# Altium schematic sheet Motor.SchDoc
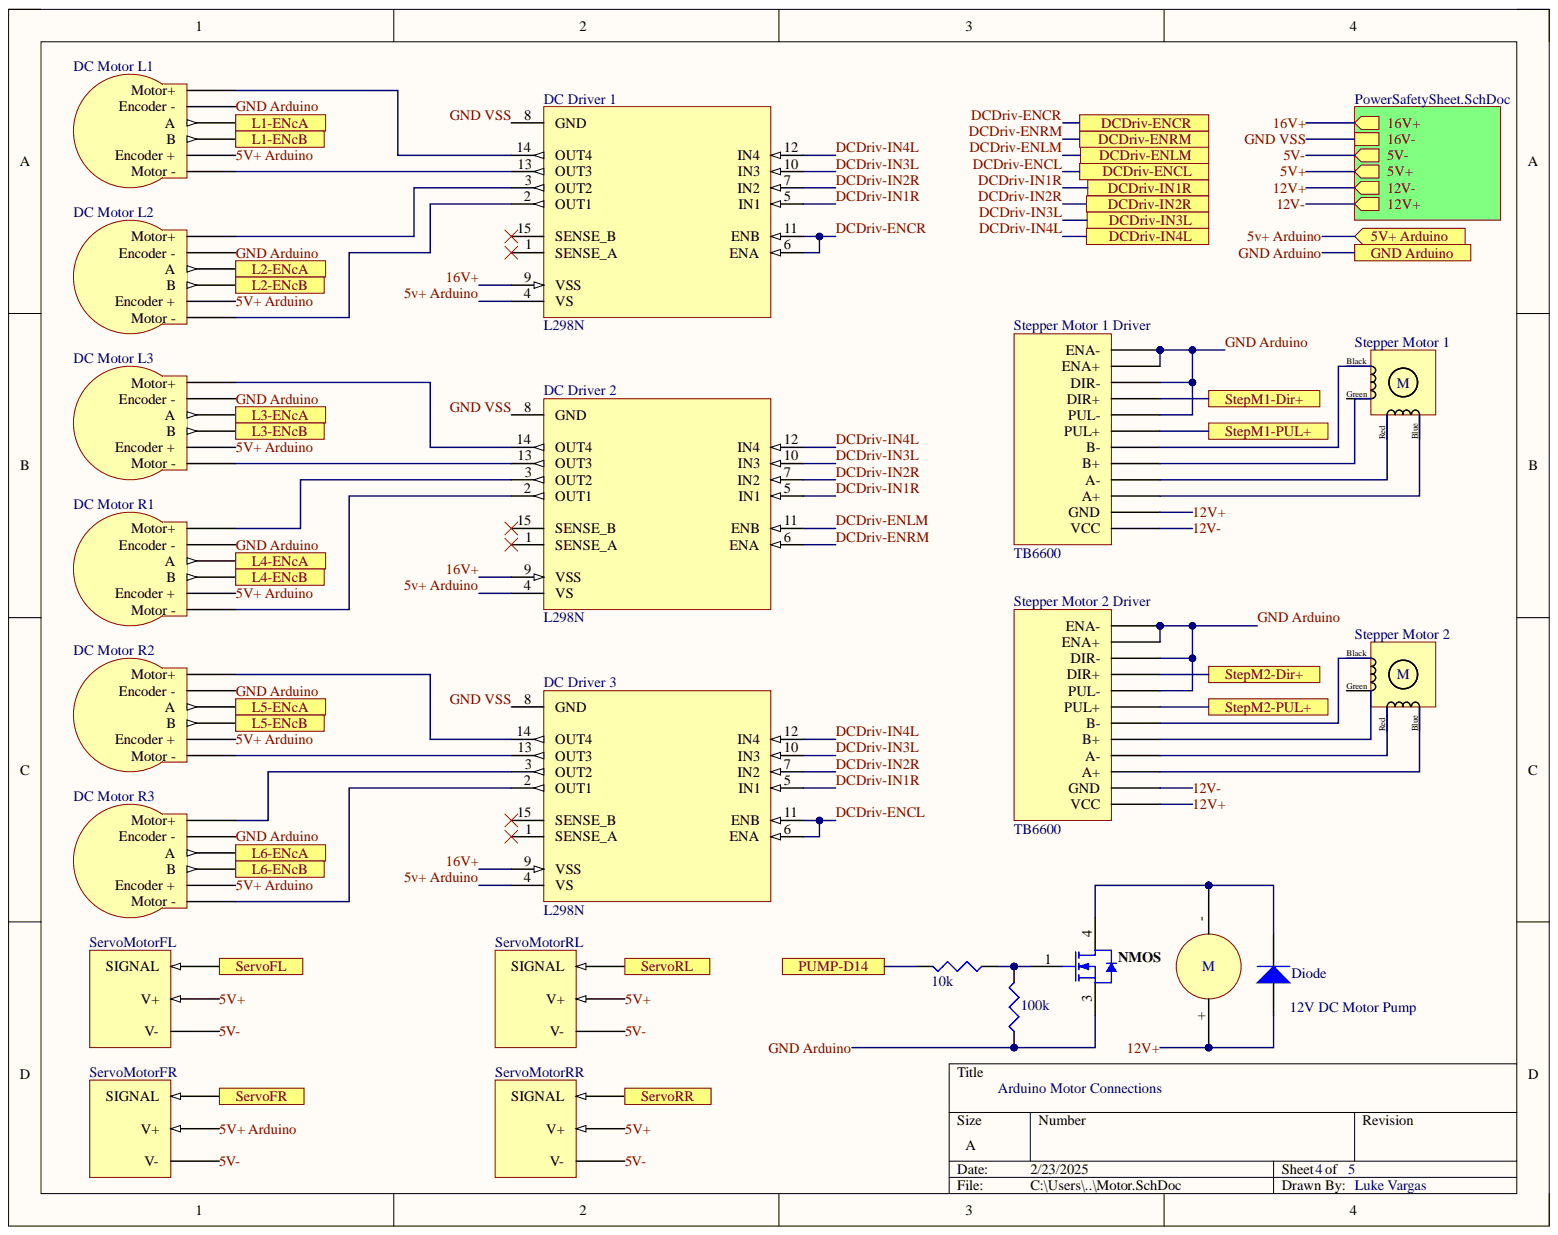
[diagram p0: Motor.SchDoc]

## Parts
10k  value 100k  [RESA60-630X240]  (hidden comment: MFR-25FBF52-10K)
12V_DC_Motor_Pump  12V DC Motor Pump
?  value 10k  [RESA60-630X240]  (hidden comment: MFR-25FBF52-10K)
DC_Driver_1  L298N  [L298N]
DC_Driver_2  L298N  [L298N]
DC_Driver_3  L298N  [L298N]
DC_Motor_L1  MotorWithEncoder  (value not drawn)
DC_Motor_L2  MotorWithEncoder  (value not drawn)
DC_Motor_L3  MotorWithEncoder  (value not drawn)
DC_Motor_R1  MotorWithEncoder  (value not drawn)
DC_Motor_R2  MotorWithEncoder  (value not drawn)
DC_Motor_R3  MotorWithEncoder  (value not drawn)
Diode  1N4007  [FAIR-DO-41-2]  (value not drawn)
NMOS  NTD3055L104G  [ONSC-DPAK-3-369C_V]  (value not drawn)
ServoMotorFL  ServoMotor
ServoMotorFR  ServoMotor
ServoMotorRL  ServoMotor
ServoMotorRR  ServoMotor
Stepper_Motor_1  Stepper Motor 1
Stepper_Motor_1_Driver  TB6600
Stepper_Motor_2  Stepper Motor 2
Stepper_Motor_2_Driver  TB6600

## Ports
5V+ Arduino, DCDriv-ENCL, DCDriv-ENCR, DCDriv-ENLM, DCDriv-ENRM, DCDriv-IN1R, DCDriv-IN2R, DCDriv-IN3L, DCDriv-IN4L, GND Arduino, L1-ENcA, L1-ENcB, L2-ENcA, L2-ENcB, L3-ENcA, L3-ENcB, L4-ENcA, L4-ENcB, L5-ENcA, L5-ENcB, L6-ENcA, L6-ENcB, PUMP-D14, ServoFL, ServoFR, ServoRL, ServoRR, StepM1-Dir+, StepM1-PUL+, StepM2-Dir+, StepM2-PUL+

## Nets
5V+: ServoMotorFL.+ ServoMotorRL.+ ServoMotorRR.+
5v+ Arduino: DC_Driver_1.4(VS) DC_Driver_2.4(VS) DC_Driver_3.4(VS) DC_Motor_L1.Encoder + DC_Motor_L2.Encoder + DC_Motor_L3.Encoder + DC_Motor_R1.Encoder + DC_Motor_R2.Encoder + DC_Motor_R3.Encoder + ServoMotorFR.+ ; port 5V+ Arduino
5V-: ServoMotorFL.- ServoMotorFR.- ServoMotorRL.- ServoMotorRR.-
12V+: 12V_DC_Motor_Pump.+ Diode.2 Stepper_Motor_1_Driver.GND Stepper_Motor_2_Driver.VCC
12V-: Stepper_Motor_1_Driver.VCC Stepper_Motor_2_Driver.GND
16V+: DC_Driver_1.9(VSS) DC_Driver_2.9(VSS) DC_Driver_3.9(VSS)
DCDriv-ENCL: DC_Driver_3.6(ENA) DC_Driver_3.11(ENB) ; port DCDriv-ENCL
DCDriv-ENCR: DC_Driver_1.6(ENA) DC_Driver_1.11(ENB) ; port DCDriv-ENCR
DCDriv-ENLM: DC_Driver_2.11(ENB) ; port DCDriv-ENLM
DCDriv-ENRM: DC_Driver_2.6(ENA) ; port DCDriv-ENRM
DCDriv-IN1R: DC_Driver_1.5(IN1) DC_Driver_2.5(IN1) DC_Driver_3.5(IN1) ; port DCDriv-IN1R
DCDriv-IN2R: DC_Driver_1.7(IN2) DC_Driver_2.7(IN2) DC_Driver_3.7(IN2) ; port DCDriv-IN2R
DCDriv-IN3L: DC_Driver_1.10(IN3) DC_Driver_2.10(IN3) DC_Driver_3.10(IN3) ; port DCDriv-IN3L
DCDriv-IN4L: DC_Driver_1.12(IN4) DC_Driver_2.12(IN4) DC_Driver_3.12(IN4) ; port DCDriv-IN4L
GND Arduino: 10k.1 DC_Motor_L1.Encoder - DC_Motor_L2.Encoder - DC_Motor_L3.Encoder - DC_Motor_R1.Encoder - DC_Motor_R2.Encoder - DC_Motor_R3.Encoder - NMOS.3 Stepper_Motor_1_Driver.DIR- Stepper_Motor_1_Driver.ENA+(5V) Stepper_Motor_1_Driver.ENA- Stepper_Motor_1_Driver.PUL- Stepper_Motor_2_Driver.DIR- Stepper_Motor_2_Driver.ENA+(5V) Stepper_Motor_2_Driver.ENA- Stepper_Motor_2_Driver.PUL- ; port GND Arduino
GND VSS: DC_Driver_1.8(GND) DC_Driver_2.8(GND) DC_Driver_3.8(GND)
L1-ENcA: DC_Motor_L1.A ; port L1-ENcA
L1-ENcB: DC_Motor_L1.B ; port L1-ENcB
L2-ENcA: DC_Motor_L2.A ; port L2-ENcA
L2-ENcB: DC_Motor_L2.B ; port L2-ENcB
L3-ENcA: DC_Motor_L3.A ; port L3-ENcA
L3-ENcB: DC_Motor_L3.B ; port L3-ENcB
L4-ENcA: DC_Motor_R1.A ; port L4-ENcA
L4-ENcB: DC_Motor_R1.B ; port L4-ENcB
L5-ENcA: DC_Motor_R2.A ; port L5-ENcA
L5-ENcB: DC_Motor_R2.B ; port L5-ENcB
L6-ENcA: DC_Motor_R3.A ; port L6-ENcA
L6-ENcB: DC_Motor_R3.B ; port L6-ENcB
PUMP-D14: ?.2 ; port PUMP-D14
ServoFL: ServoMotorFL.SIGNAL ; port ServoFL
ServoFR: ServoMotorFR.SIGNAL ; port ServoFR
ServoRL: ServoMotorRL.SIGNAL ; port ServoRL
ServoRR: ServoMotorRR.SIGNAL ; port ServoRR
StepM1-Dir+: Stepper_Motor_1_Driver.DIR+ ; port StepM1-Dir+
StepM1-PUL+: Stepper_Motor_1_Driver.PUL+ ; port StepM1-PUL+
StepM2-Dir+: Stepper_Motor_2_Driver.DIR+ ; port StepM2-Dir+
StepM2-PUL+: Stepper_Motor_2_Driver.PUL+ ; port StepM2-PUL+
Net10k_2: 10k.2 ?.1 NMOS.1
Net12V DC Motor Pump_-: 12V_DC_Motor_Pump.- Diode.1 NMOS.4
NetDC Driver 1_2: DC_Driver_1.2(OUT1) DC_Motor_L2.Motor -
NetDC Driver 1_3: DC_Driver_1.3(OUT2) DC_Motor_L2.Motor +(Motor+)
NetDC Driver 1_13: DC_Driver_1.13(OUT3) DC_Motor_L1.Motor -
NetDC Driver 1_14: DC_Driver_1.14(OUT4) DC_Motor_L1.Motor +(Motor+)
NetDC Driver 2_2: DC_Driver_2.2(OUT1) DC_Motor_R1.Motor -
NetDC Driver 2_3: DC_Driver_2.3(OUT2) DC_Motor_R1.Motor +(Motor+)
NetDC Driver 2_13: DC_Driver_2.13(OUT3) DC_Motor_L3.Motor -
NetDC Driver 2_14: DC_Driver_2.14(OUT4) DC_Motor_L3.Motor +(Motor+)
NetDC Driver 3_2: DC_Driver_3.2(OUT1) DC_Motor_R3.Motor -
NetDC Driver 3_3: DC_Driver_3.3(OUT2) DC_Motor_R3.Motor +(Motor+)
NetDC Driver 3_13: DC_Driver_3.13(OUT3) DC_Motor_R2.Motor -
NetDC Driver 3_14: DC_Driver_3.14(OUT4) DC_Motor_R2.Motor +(Motor+)
NetStepper Motor 1 Driver_A+: Stepper_Motor_1.Blue Stepper_Motor_1_Driver.A+
NetStepper Motor 1 Driver_A-: Stepper_Motor_1.Red Stepper_Motor_1_Driver.A-
NetStepper Motor 1 Driver_B+: Stepper_Motor_1.Green Stepper_Motor_1_Driver.B+
NetStepper Motor 1 Driver_B-: Stepper_Motor_1.Black Stepper_Motor_1_Driver.B-
NetStepper Motor 2 Driver_A+: Stepper_Motor_2.Blue Stepper_Motor_2_Driver.A+
NetStepper Motor 2 Driver_A-: Stepper_Motor_2.Red Stepper_Motor_2_Driver.A-
NetStepper Motor 2 Driver_B+: Stepper_Motor_2.Green Stepper_Motor_2_Driver.B+
NetStepper Motor 2 Driver_B-: Stepper_Motor_2.Black Stepper_Motor_2_Driver.B-

Not connected: DC_Driver_1 1(SENSE_A) 15(SENSE_B); DC_Driver_2 1(SENSE_A) 15(SENSE_B); DC_Driver_3 1(SENSE_A) 15(SENSE_B)

## Library data of the parts
10k ? = CMP-009-00047-4: Axial Resistor, 10 KOhm, +/- 1%, 0.25 W, -55 to 155 degC, 2-Pin THD, RoHS, Bulk; Manufacturer: Yageo; Power: 250mW; Voltage Rating: 250V; Case/Package: Axial; Component Kind: Standard; Datasheet URL: http://www.yageo.com/documents/recent/Yageo%20LR_MFR_2013.pdf; Datasheet Version: Apr-13; Manufacturer URL: http://www.yageo.com/; Max Operating Temperature: 155°C; Min Operating Temperature: -55°C; Mounting Technology: TH; Package Description: 2-Pin Axial Lead, Dia 2.4 mm, Body Length 6.3 mm; Package Quantity: 200; Package Reference: MFR-25; Packaging: Bulk; RoHS Compliant: True; Tolerance: 1%; Library Name: Thousand Sunny
Diode = CMP-004-00007-2: General Purpose Rectifier, 1.1 V, 1.0 A, -55 to 175 degC, 2-Pin DO-41, RoHS, Tape and Reel; Manufacturer: Fairchild; Elements: 1; Forward Current: 1A; Forward Voltage: 1.1V; Max Surge Current: 30A; Package Version: Rev. 2, 09/2008; Peak Reverse Current: 5uA; Case/Package: DO-41-2; Component Kind: Standard; Datasheet URL: https://www.fairchildsemi.com/datasheets/1N/1N4007.pdf; Datasheet Version: Rev. 1.1.0, 11/2014; Manufacturer URL: https://www.fairchildsemi.com/; Max Operating Temperature: 175°C; Min Operating Temperature: -55°C; Mounting Technology: TH; Package Description: 2-Pin Axial Lead, Dia 2.375 mm, Body Length 4.63 mm; Package Quantity: 5000; Package Reference: DO-41-2; Package URL: http://www.fairchildsemi.com/dwg/DO/DO41A.pdf; Packaging: TapeandReel; Pins: 2; RoHS Compliant: True; Library Name: Thousand Sunny
NMOS = CMP-013-00013-3: Logic Level N-Channel Power MOSFET, 12 A, 60 V, -55 to 175 degC, 3-Pin DPAK, Pb-Free, Tube; Manufacturer: ON Semiconductor; Elements: 1; Input Capacitance: 440pF; Power: 48W; Rds On Max: 104mohm; Typical Rise Time: 104ns; Case/Package: DPAK; Component Kind: Standard; Datasheet URL: http://www.onsemi.cn/pub_link/Collateral/NTD3055L104-D.PDF; Datasheet Version: Rev. 10, 09/2016; Max Operating Temperature: 175°C; Min Operating Temperature: -55°C; Mounting Technology: SM; Package Description: 3-Pin Transistor Outline, Body 6.54 x 6.095 mm, Pitch 2.29 mm; Package Quantity: 75; Package Reference: DPAK-3-369C; Packaging: Rail/Tube; Pins: 3; RoHS Compliant: True; Library Name: Thousand Sunny
ServoMotorFL ServoMotorFR ServoMotorRL ServoMotorRR = ServoMotor: High Torque Servo Motor; Component Kind: Standard; Library Name: RushbotWiring.SCHLIB
Stepper_Motor_1 Stepper_Motor_2 = StepperMotor-001-1: Stepper Motor; Stepper Motor Type: dual Shaft; Motor Size: 42Mm; Coil Type: bipolar; Step Angle: 1.8?; Torque Max:...; Manufacturer: LIN; Manufacturer Part Number: 4118L-01RO; Component Kind: Standard; Component Type: Miscellaneous; Library Name: RushbotWiring.SCHLIB
12V_DC_Motor_Pump = 12V DC Motor Pump: Component Kind: Standard; Library Name: RushbotWiring.SCHLIB
DC_Driver_1-DC_Driver_3 = L298N: Component Kind: Standard; Library Name: L298N.SchLib
DC_Motor_L1-DC_Motor_L3 DC_Motor_R1-DC_Motor_R3 = MotorWithEncoder: Component Kind: Standard; Library Name: RushbotWiring.SCHLIB
Stepper_Motor_1_Driver Stepper_Motor_2_Driver = TB6600: Component Kind: Standard; Library Name: RushbotWiring.SCHLIB
